# Altium schematic sheet USB.SchDoc(USB)
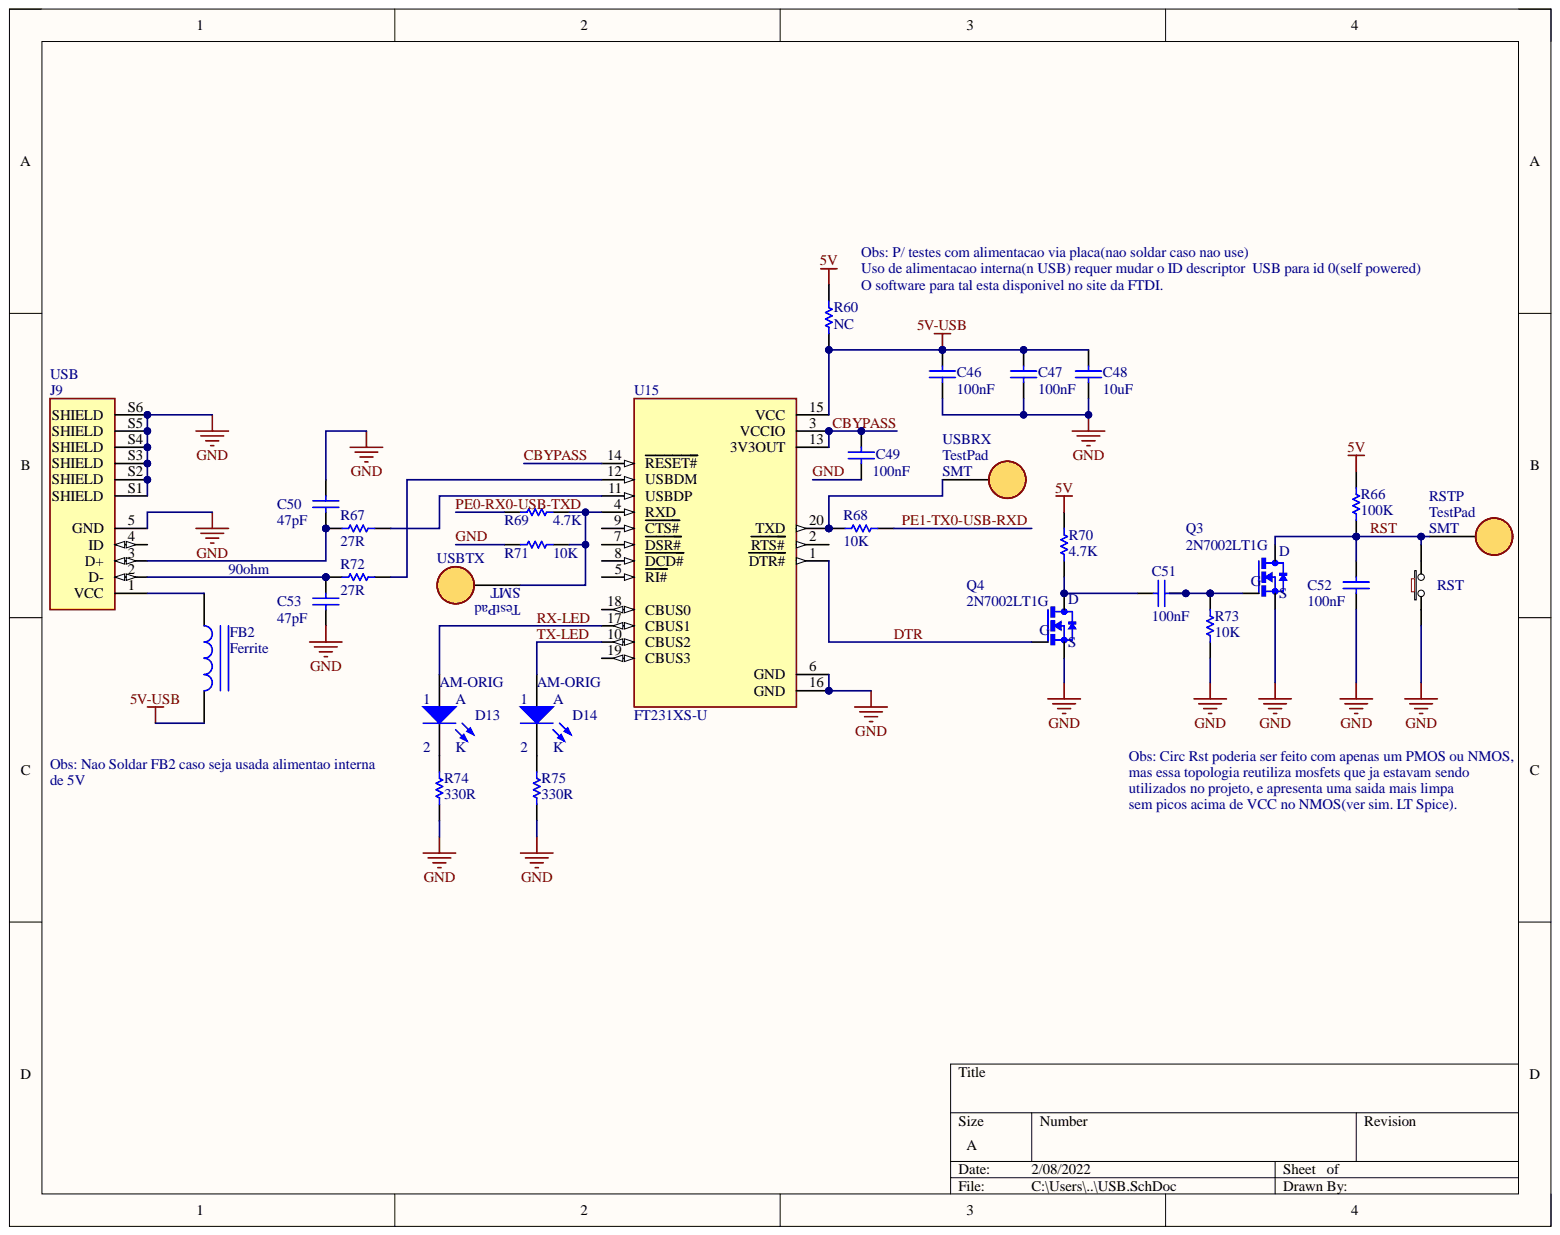
[diagram p0: USB.SchDoc]

## Parts
C46  100nF  [CAPC2012X65N]
C47  100nF  [CAPC2012X65N]
C48  10uF  value 100nF  [CAPGC2012X65N]
C49  100nF  [CAPC2012X65N]
C50  47pF  [CAPC2012X65N]
C51  100nF  [CAPC2012X65N]
C52  100nF  [CAPC2012X65N]
C53  47pF  [CAPC2012X65N]
D13  AM-ORIG  [LED_7012X1]
D14  AM-ORIG  [LED_7012X1]
FB2  Ferrite  [RESC2012X65N]
J9  USB  [XKB_U254-051T-4BH83-F1S]
Q3  2N7002LT1G  [SOT23]
Q4  2N7002LT1G  [SOT23]
R60  NC  [CAPC2012X65N - unpopulated]
R66  100K  [RESC2012X65N]
R67  27R  [RESC2012X65N]
R68  10K  [RESC2012X65N]
R69  4.7K  [RESC2012X65N]
R70  4.7K  [RESC2012X65N]
R71  10K  [RESC2012X65N]
R72  27R  [RESC2012X65N]
R73  10K  [RESC2012X65N]
R74  330R  [RESC2012X65N]
R75  330R  [RESC2012X65N]
RST  PUSH BUTTON  [SW_PTS636_SM43J_SMTR_LFS]  (value not drawn)
RSTP  (no value)  [TestPAD-SMT-SIMPLES]
U15  FT231XS-U  [SOP63P600X175-20N]
USBRX  (no value)  [TestPAD-SMT-SIMPLES]
USBTX  (no value)  [TestPAD-SMT-SIMPLES]

## Nets
5V: R60.2 R66.1 R70.1
5V-USB: C46.1 C47.1 C48.1 FB2.2 R60.1 U15.15(VCC)
CBYPASS: C49.1 U15.3(VCCIO) U15.13(3V3OUT) U15.14(RESET#)
DTR: Q4.1 U15.1(DTR#)
GND: C46.2 C47.2 C48.2 C49.2 C50.2 C52.2 C53.2 J9.5(GND) J9.S1(SHIELD) J9.S2(SHIELD) J9.S3(SHIELD) J9.S4(SHIELD) J9.S5(SHIELD) J9.S6(SHIELD) Q3.2 Q4.2 R71.2 R73.2 R74.1 R75.1 RST.1 U15.6(GND) U15.16(GND)
PE0-RX0-USB-TXD: R69.2
PE1-TX0-USB-RXD: R68.2
RST: C52.1 Q3.3 R66.2 RST.2 RSTP.1
RX-LED: D13.A U15.17(CBUS1)
TX-LED: D14.A U15.10(CBUS2)
NetC50_1: C50.1 J9.3(D+) R67.2
NetC51_1: C51.1 Q4.3 R70.2
NetC51_2: C51.2 Q3.1 R73.1
NetC53_1: C53.1 J9.2(D-) R72.2
NetD13_C: D13.C R74.2
NetD14_C: D14.C R75.2
NetFB2_1: FB2.1 J9.1(VCC)
NetR67_1: R67.1 U15.11(USBDP)
NetR68_1: R68.1 U15.20(TXD) USBRX.1
NetR69_1: R69.1 R71.1 U15.4(RXD) USBTX.1
NetR72_1: R72.1 U15.12(USBDM)

Not connected: J9 4(ID); U15 2(RTS#) 5(RI#) 7(DSR#) 8(DCD#) 9(CTS#) 18(CBUS0) 19(CBUS3)

## Library data of the parts
C46-C49 C51 C52 = Cap: Capacitor; Component Kind: Standard; LatestRevisionDate: 17-Jul-2002; LatestRevisionNote: Re-released for DXP Platform.; Library Name: Miscellaneous Devices.IntLib; PackageDescription: Radial Cap, Thru-Hole; 2 Leads; 0.3 in Pin Spacing; PackageReference: RAD-0.3; Published: 8-Jun-2000; Publisher: Altium Limited
  C46 C47 C49 C51 C52: Value: 100nF
C50 C53 = Cap: Capacitor; Value: 100nF; Component Kind: Standard; LatestRevisionDate: 17-Jul-2002; LatestRevisionNote: Re-released for DXP Platform.; Library Name: Miscellaneous Devices.IntLib; PackageDescription: Radial Cap, Thru-Hole; 2 Leads; 0.3 in Pin Spacing; PackageReference: RAD-0.3; Published: 8-Jun-2000; Publisher: Altium Limited
D13 D14 = LED: Component Kind: Standard; Library Name: Zele_Sch.SchLib
FB2 = Inductor Iron: Magnetic-Core Inductor; Component Kind: Standard; LatestRevisionDate: 17-Jul-2002; LatestRevisionNote: Re-released for DXP Platform.; Library Name: Miscellaneous Devices.IntLib; PackageDescription: Axial Device, Thru-Hole; 2 Leads; 0.9 in Pin Spacing; PackageReference: AXIAL-0.9; Published: 24-Mar-1999; Signal Integrity: Inductor Iron; Simulation: INDUCTOR; Publisher: Altium Limited
J9 = U254-051T-4BH83-F1S: USB - Micro B Female USB 2 5 SMD USB Connectors RoHS; MF: XKB Industrial Precision; Availability: Unavailable; Check_prices: https://www.snapeda.com/parts/U254-051T-4BH83-F1S/XKB%20Industrial%20Precision/view-part/4967971/?ref=eda; Component Kind: Standard; Library Name: MicroUSB U254-051T-4BH83-F1S.SchLib; MP: U254-051T-4BH83-F1S; Package: Package; Price: None; SnapEDA_Link: https://www.snapeda.com/parts/U254-051T-4BH83-F1S/XKB%20Industrial%20Precision/view-part/4967971/?ref=snap
Q3 Q4 = FDN359AN: N-Channel 30V 2.7A (Ta) 500mW (Ta) Surface Mount SuperSOT-3; MF: ON Semiconductor; Component Kind: Standard; Digi-Key_Part_Number: FDN359ANCT-ND; MP: FDN359AN; Package: SC-59 ON Semiconductor; SnapEDA_Link: https://www.snapeda.com/parts/FDN359AN/ON%20Semiconductor/view-part/1737161/?ref=snap; Library Name: NMOS-SOT23.SchLib
R60 R66-R68 R70 R72-R75 = Res1: Resistor; Value: 220; Component Kind: Standard; LatestRevisionDate: 17-Jul-2002; LatestRevisionNote: Re-released for DXP Platform.; Library Name: Miscellaneous Devices.IntLib; PackageDescription: Axial Device, Thru-Hole; 2 Leads; 0.3 in Pin Spacing; PackageReference: AXIAL-0.3; Published: 8-Jun-2000; Signal Integrity: Res; Simulation: RESISTOR; Publisher: Altium Limited
R69 R71 = Res1: Resistor; Value: 47K; Component Kind: Standard; LatestRevisionDate: 17-Jul-2002; LatestRevisionNote: Re-released for DXP Platform.; Library Name: Miscellaneous Devices.IntLib; PackageDescription: Axial Device, Thru-Hole; 2 Leads; 0.3 in Pin Spacing; PackageReference: AXIAL-0.3; Published: 8-Jun-2000; Signal Integrity: Res; Simulation: RESISTOR; Publisher: Altium Limited
RST = PUSHB: Component Kind: Standard; Library Name: Zele_Sch.SchLib
RSTP USBRX USBTX = TestPad: Component Kind: Standard; Library Name: TestPadSMT.SchLib
U15 = FT231XS-U: USB Bridge, USB to UART USB 2.0 UART Interface 20-SSOP; MF: FTDI,; Availability: Unavailable; Component Kind: Standard; Library Name: FT231XS-U.SchLib; MP: FT231XS-U; Package: SSOP-20 FTDI; Price: None; SnapEDA_Link: https://www.snapeda.com/parts/FT231XS-U/FTDI%2C%20Future%20Technology%20Devices%20International%20Ltd/view-part/52942/?ref=snap
